# Altium schematic sheet REFERENCE.SchDoc
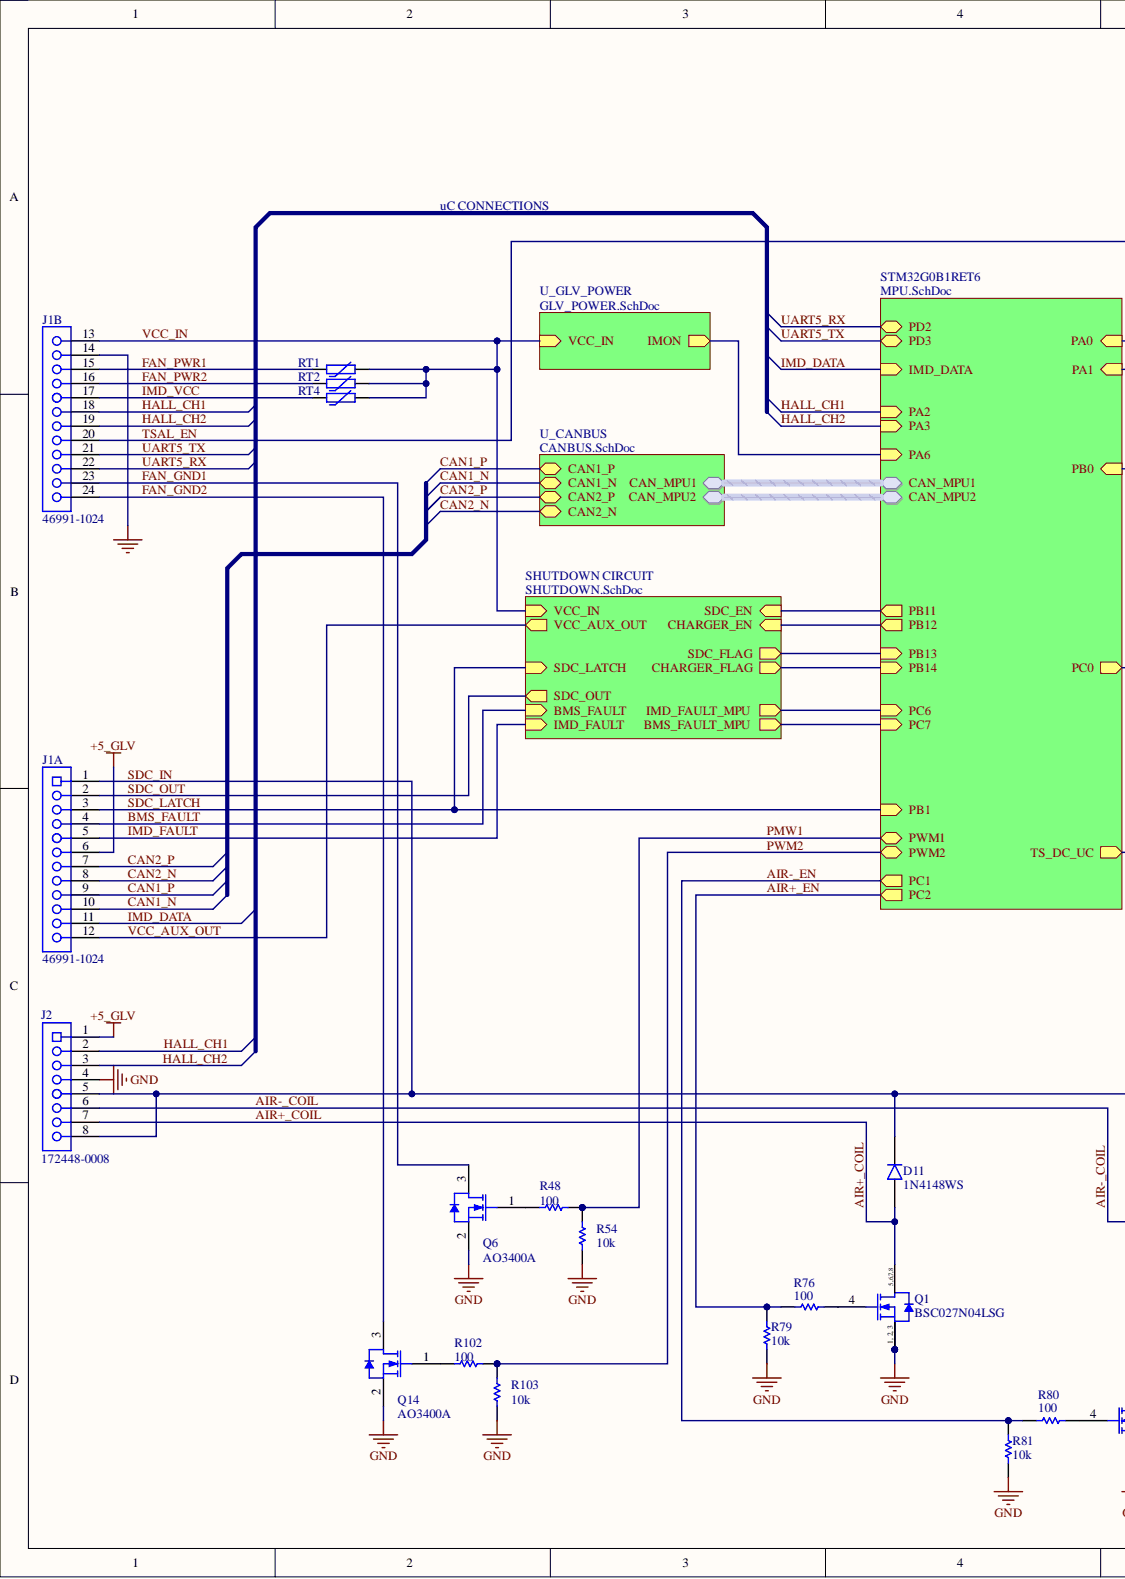
[diagram p0: REFERENCE.SchDoc, left]
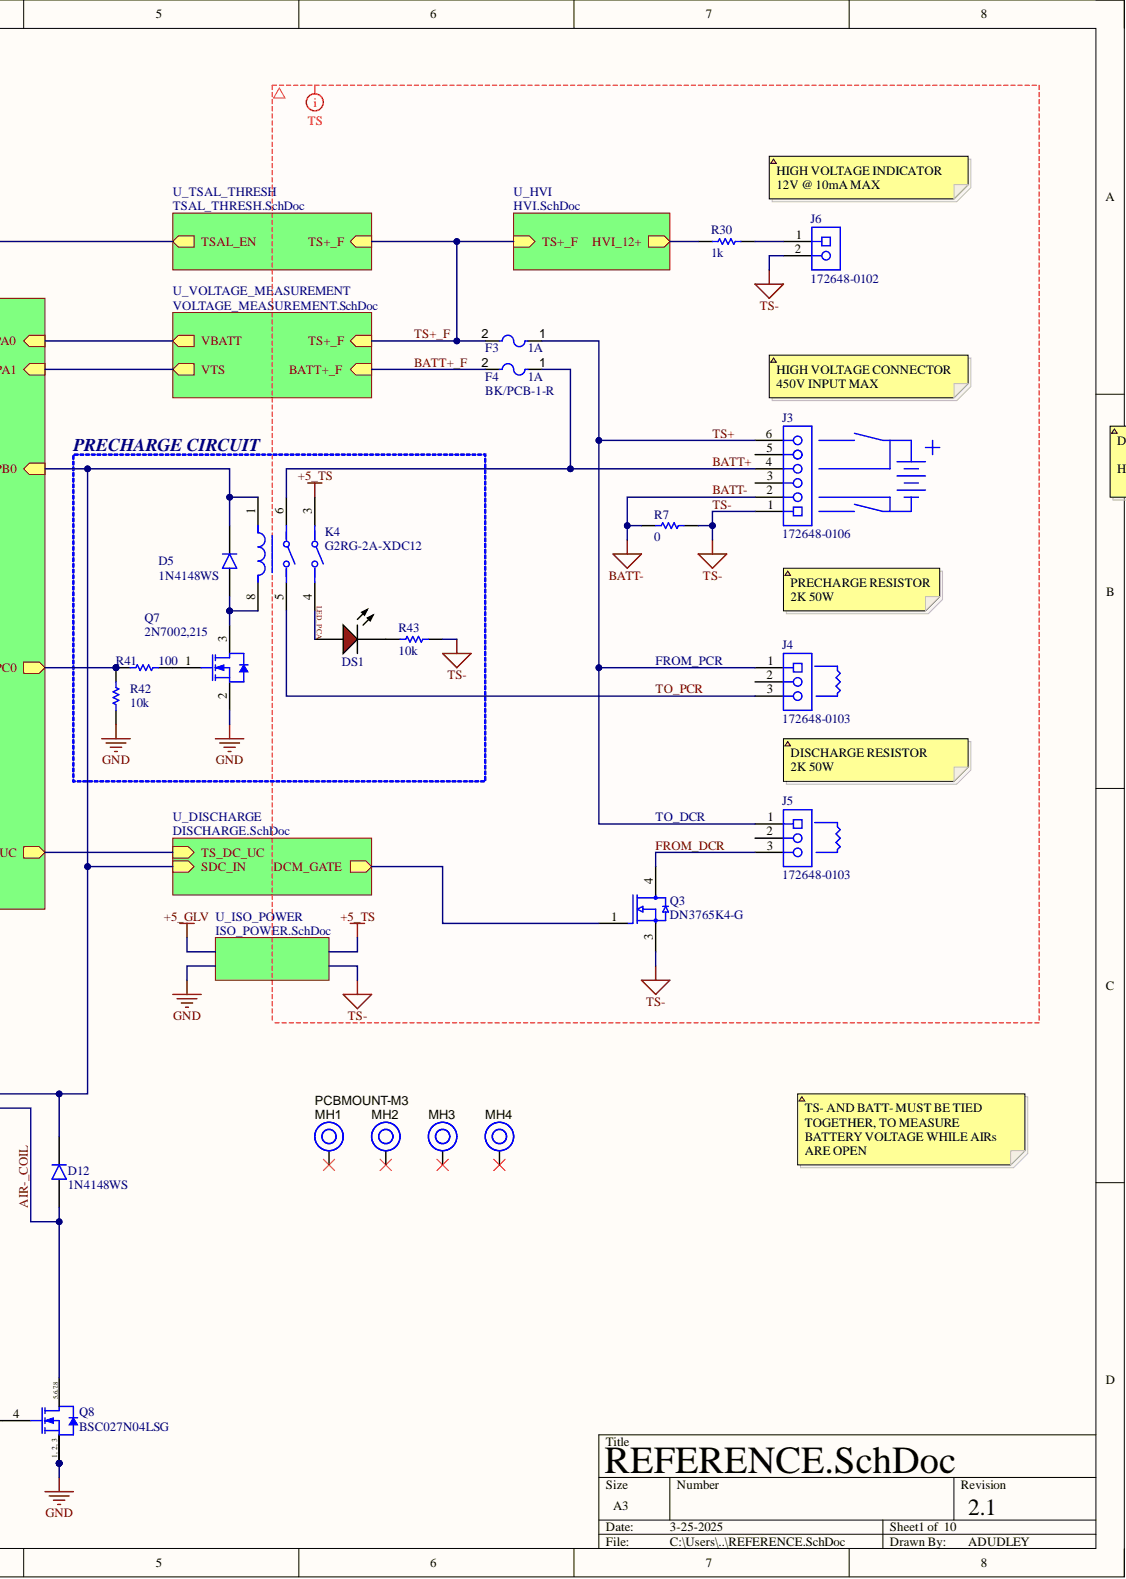
[diagram p1: REFERENCE.SchDoc, right]

## Parts
D5  1N4148WS  [FAIR-SOD-323_V]
D11  1N4148WS  [FAIR-SOD-323_V]
D12  1N4148WS  [FAIR-SOD-323_V]
DS1  19-217/R6C-AL1M2VY/3T  [EVRL-0603-2_V]  (value not drawn)
F3  Current Rating: 1A  [BK_PCB-1-R-Footprint-1]  (hidden comment: BK/PCB-1-R)
F4  BK/PCB-1-R  Current Rating: 1A  [BK_PCB-1-R-Footprint-1]
J1  46991-1024  [46991-1024-Footprint-1]  (parts A,B)
J2  172448-0008  [FP-172448-0008-MFG]
J3  172648-0106  [172648-0106-Footprint-1]
J4  172648-0103  [172648-0103-Footprint-1]
J5  172648-0103  [172648-0103-Footprint-1]
J6  172648-0102  [FP-172648-0102-MFG]
K4  G2RG-2A-XDC12  [G2RG-2A-XDC12-Footprint-1]
MH1  PCBMOUNT-M3  [PCBMOUNT-M3-Footprint-1]
MH2  PCBMOUNT-M3  [PCBMOUNT-M3-Footprint-1]
MH3  PCBMOUNT-M3  [PCBMOUNT-M3-Footprint-1]
MH4  PCBMOUNT-M3  [PCBMOUNT-M3-Footprint-1]
Q1  BSC027N04LSG  [INF-PG-TDSON-8-1_V]
Q3  DN3765K4-G  [FP-K4-IPC_C]
Q6  AO3400A  [NXP-SOT23-3_V]
Q7  2N7002,215  [NXP-SOT23-3_V]
Q8  BSC027N04LSG  [INF-PG-TDSON-8-1_V]
Q14  AO3400A  [NXP-SOT23-3_V]
R7  value 0  [RESC3116X70X50ML13T20]  (hidden comment: Jumper 1206)
R30  value 1k  [RESC3116X70X50ML13T20]  (hidden comment: 1K 1% 1206)
R41  value 100  [RESC1608X55X25ML10T15]  (hidden comment: 100R 1 0603)
R42  value 10k  [RESC1608X55X25ML10T15]  (hidden comment: 10K 1 0603)
R43  value 10k  [RESC1608X55X25ML10T15]  (hidden comment: 10K 1 0603)
R48  value 100  [RESC1608X55X25ML10T15]  (hidden comment: 100R 1 0603)
R54  value 10k  [RESC1608X55X25ML10T15]  (hidden comment: 10K 1 0603)
R76  value 100  [RESC1608X55X25ML10T15]  (hidden comment: 100R 1 0603)
R79  value 10k  [RESC1608X55X25ML10T15]  (hidden comment: 10K 1 0603)
R80  value 100  [RESC1608X55X25ML10T15]  (hidden comment: 100R 1 0603)
R81  value 10k  [RESC1608X55X25ML10T15]  (hidden comment: 10K 1 0603)
R102  value 100  [RESC1608X55X25ML10T15]  (hidden comment: 100R 1 0603)
R103  value 10k  [RESC1608X55X25ML10T15]  (hidden comment: 10K 1 0603)
RT1  MINISMDC260F/16-2  [FP-MINISMDC260F-16-2-MFG]  (value not drawn)
RT2  MINISMDC260F/16-2  [FP-MINISMDC260F-16-2-MFG]  (value not drawn)
RT4  MINISMDC260F/16-2  [FP-MINISMDC260F-16-2-MFG]  (value not drawn)

## Nets
+5_GLV: J1.6 J2.1
+5_TS: K4.3
GND: J1.14 J2.4 Q1.1 Q1.2 Q1.3 Q6.2 Q7.2 Q8.1 Q8.2 Q8.3 Q14.2 R42.1 R54.1 R79.1 R81.1 R103.1
AIR+_COIL: D11.1 J2.7 Q1.5,6,7,8
AIR+_EN: R76.1 R79.2
AIR-_COIL: D12.1 J2.6 Q8.5,6,7,8
AIR-_EN: R80.1 R81.2
BATT+: F4.1 J3.4 K4.6
BATT+_F: F4.2
BATT-: J3.2 R7.1
BMS_FAULT: J1.4
CAN1_N: J1.10
CAN1_P: J1.9
CAN2_N: J1.8
CAN2_P: J1.7
FAN_GND1: J1.23 Q6.3
FAN_GND2: J1.24 Q14.3
FAN_PWR1: J1.15 RT1.1
FAN_PWR2: J1.16 RT2.1
FROM_DCR: J5.3 Q3.4
HALL_CH1: J1.18 J2.2
HALL_CH2: J1.19 J2.3
IMD_DATA: J1.11
IMD_FAULT: J1.5
IMD_VCC: J1.17 RT4.1
LED_PCA: DS1.1 K4.4
PMW1: R48.2 R54.2
PWM2: R102.2 R103.2
SDC_IN: D5.2 D11.2 D12.2 J1.1 J2.5 J2.8 K4.1
SDC_LATCH: J1.3
SDC_OUT: J1.2
TO_PCR: J4.3 K4.5
TS+: F3.1 J3.6 J4.1 J5.1
TS+_F: F3.2
TS-: J3.1 J6.2 Q3.3 R7.2 R43.1
TSAL_EN: J1.20
UART5_RX: J1.22
UART5_TX: J1.21
VCC_AUX_OUT: J1.12
VCC_IN: J1.13 RT1.2 RT2.2 RT4.2
NetD5_1: D5.1 K4.8 Q7.3
NetDS1_2: DS1.2 R43.2
NetJ3_3: J3.3
NetJ3_5: J3.5
NetJ4_2: J4.2
NetJ5_2: J5.2
NetJ6_1: J6.1 R30.2
NetMH1_1: MH1.1
NetMH2_1: MH2.1
NetMH3_1: MH3.1
NetMH4_1: MH4.1
NetQ1_4: Q1.4 R76.2
NetQ3_1: Q3.1
NetQ6_1: Q6.1 R48.1
NetQ7_1: Q7.1 R41.2
NetQ8_4: Q8.4 R80.2
NetQ14_1: Q14.1 R102.1
NetR30_1: R30.1
NetR41_1: R41.1 R42.2
N00001:
N00002:
N00003:
N00004:
N00005:
N00006:
N00007:
N00008:
N00009:
N00010:
N00011:
N00012:
N00013:
N00014:

## Library data of the parts
D5 D11 D12 = CMP-004-00001-4: Small Signal Diode, 75 V, 0.15 A, -55 to 150 degC, 2-Pin SOD-323, RoHS, Tape and Reel; Manufacturer: Fairchild; Capacitance: -323f; Forward Current: 150mA; Forward Voltage: 1V; Peak Reverse Current: 5uA; Recovery Time: 4ns; Case/Package: SOD-323; Component Kind: Standard; Datasheet URL: https://www.fairchildsemi.com/datasheets/1N/1N4148WS.pdf; Datasheet Version: Rev. 1.2, 03/2015; LCSC Part Number: C2128; Manufacturer URL: https://www.fairchildsemi.com/; Max Operating Temperature: 150°C; Min Operating Temperature: -55°C; Mounting Technology: SM; Package Description: 2-Pin Small Outline Diode Flat Lead, Body 1.7 x 1.25 mm; Package Quantity: 3000; Package Reference: SOD-323; Packaging: TapeandReel; Pins: 2; RoHS Compliant: True; Library Name: Dalhousie Formula SAE
DS1 = CMP-007-00004-3: Chip LED, Red, 5 V, 25 mA, -40 to 85 degC, 2-Pin SMD (0603), RoHS, Tape and Reel; Manufacturer: Everlight Electronics; Forward Voltage: 2.2V; Lens Style: Clear; Case/Package: 0603; Colour: Red; Component Kind: Standard; Datasheet URL: https://datasheet.ciiva.com/4688/el-19-217-r6c-al1m2vy-3tpdf-187026-4688713.pdf; Datasheet Version: Rev. 1, 01/2005; LCSC Part Number: C2286; Manufacturer URL: http://www.everlight.com/; Mounting Technology: SM; Package Description: 2-Pin Surface Mount Device, Body 1.6 x 0.8 mm; Package Reference: 0603; RoHS Compliant: False; Library Name: Dalhousie Formula SAE
F3 F4 = CMP-005-00016-2: Electric Fuse, Fast Blow, 1A, 250VAC, 450VDC, 50A (IR), Through Hole; Body Breadth: 4.67inch; Body Height: 8.77mm; Body Length or Diameter: 8.89mm; Fuse Class: Supplemental; Joule-integral-Nom: 0.02J; Reference Standard: UL; Trip Time or Delay: 14400s; Voltage Rating: 250V; Voltage Rating (DC): 450V; Blow Characteristic: FAST; Circuit Protection Type: Electric Fuse; Component Kind: Standard; LCSC Part Number: C3160672; Mounting Technology: Through Hole; Packaging: Box; Rated Breaking Capacity: 50A; Reach SVHC Compliant: Yes; RoHS Compliant: Yes; Termination: Pin Wire; Voltage Rating (AC): 250V; Library Name: Dalhousie Formula SAE
J1 = CMP-002-00135-3: Rectangular Power Connector, 24 Contact(s), Male, Solder Terminal; Board Mounting Option: HOLE .064-.072; Body Breadth: 0.378inch; Body Depth: 0.796inch; Body Length: 2.031inch; Connector Type: Power,Rectangular; Contact Resistance: 10mR; Contact Style: SQ PIN-SKT; Insulation Resistance: 1GR; Mixed Contacts: Yes; Mounting Option 1: LOCKING; Number Of Connectors: 1; Number of Contacts: 24; Plating Thickness: 100uin; Reliability: COMMERCIAL; Throw Configuration: S0P24; Component Kind: Standard; Contact Finish - Termination: TIN OVER NICKEL; Contact Gender: Male; Insulation Color: Black; Insulation Material: Polymer; JESD-609 Code: e3; Max Operating Temperature: 105°C; Min Operating Temperature: -40°C; Mounting Style: RIGHT ANGLE; Mounting Technology: Board; Reach SVHC Compliant: Yes; RoHS Compliant: Yes; Termination: Solder; Library Name: Dalhousie Formula SAE
J2 = CMP-002-00093-3: Mini-Fit Jr. Header, Dual Row, Right-Angle, with Snap-in Plastic Peg PCB  Lock, 8 Circuits, PA Polyamide Nylon 6/6 94V-2, Tin (Sn) Plating, Glow-Wire Capable; Glow Wire Compliant: Compliant; Number of Circuits: 8; Pitch: 0.165inch; Color: Black; Component Kind: Standard; Contact Plating: Tin; Contact Type: Male Pin; Fastening Type: Ramp; Mounting Technology: Through Hole; Number of Positions: 8; Number of Rows: 2; Orientation: Right Angle; Packaging: Tray; RoHS Compliant: Yes; Row Spacing: 0.165inch; Series: Mini-Fit® Jr™ 172448; Termination: Solder; Library Name: Dalhousie Formula SAE
J3 = CMP-002-00099-2: Conn Power HDR 6 POS 4.2mm Solder RA Thru-Hole Tray; Component Kind: Standard; Library Name: Dalhousie Formula SAE
J4 J5 = CMP-002-00104-2: Rectangular Power Connector; Connector Type: Power,Rectangular; Component Kind: Standard; Reach SVHC Compliant: Yes; RoHS Compliant: Yes; Library Name: Dalhousie Formula SAE
J6 = CMP-002-00101-3: Cross Referenced to MOLEX - Part MOL172648-0102; Component Kind: Standard; Library Name: Dalhousie Formula SAE
K4 = CMP-008-00013-2: Power Relay DPST-NO 500VDC 12VDC PC Pins Through Hole Tray; Coil Current: 66.6 mA; Coil Resistance: 180 Ohms; Contact Form: DPST-NO (2 Form A); Contact Rating (Current): 10A; Switching Voltage: 500VDC - Max; Coil Voltage: 12VDC; Component Kind: Standard; Library Name: Dalhousie Formula SAE
MH1-MH4 = CMP-00028-00008-4: Mounting hole, M3 screw; Component Kind: Standard; Library Name: Dalhousie Formula SAE
Q1 Q8 = CMP-013-00042-8: Power Field-Effect Transistor, 24A I(D), 40V, 0.0041ohm, 1-Element, N-Channel, Silicon, Metal-oxide Semiconductor-FET; Case Connection: DRAIN; Drain Current-Max (Abs) (ID): 100A; Drain-source On Resistance-Max: 4.1mR; Operating Mode: ENHANCEMENT MODE; Power Dissipation-Max (Abs): 83W; Pulsed Drain Current-Max (IDM): 400A; Component Kind: Standard; Drain Current-Max (ID): 24A; DS Breakdown Voltage-Min: 40V; FET Technology: METAL-OXIDE SEMICONDUCTOR; LCSC Part Number: C2802513; Max Operating Temperature: 150°C; Pins: 5; Polarity/Channel Type: N-CHANNEL; RoHS Compliant: Yes; Library Name: Dalhousie Formula SAE
Q3 = CMP-013-00037-2: Trans MOSFET N-CH Si 650V 0.3A 3-Pin(2+Tab) DPAK T/R; Continuous Drain Current (ID): 300mA; Drain to Source Resistance: 8R; Fall Time: 100ns; Gate to Source Voltage (Vgs): 20V; Input Capacitance: 825pF; Max Power Dissipation: 2.5W; Number of Channels: 1; Polarity: N-CHANNEL; Case/Package: TO-252; Component Kind: Standard; Drain to Source Breakdown Voltage: 650V; Drain to Source Voltage (Vdss): 650V; Height: 2.39mm; Length: 6.73mm; Max Operating Temperature: 150°C; Min Operating Temperature: -55°C; Mounting Technology: Surface Mount; Number of Elements: 1; Package Quantity: 2000; Pins: 3; Power Dissipation: 2.5W; Rds On Max: 8R; Library Name: Dalhousie Formula SAE
Q6 Q14 = CMP-013-00044-2: Small Signal Field-Effect Transistor, 5.7A I(D), 30V, 1-Element, N-Channel, Silicon, Metal-oxide Semiconductor FET; Drain-source On Resistance-Max: 26.5mR; Number of Elements: 1; Operating Mode: ENHANCEMENT MODE; Package Body Material: Plastic; Transistor Application: SWITCHING; Component Kind: Standard; Drain Current-Max (ID): 5.7A; DS Breakdown Voltage-Min: 30V; FET Technology: METAL-OXIDE SEMICONDUCTOR; JESD-30 Code: R-PDSO-G3; LCSC Part Number: C20917; Package Shape: Rectangular; Package Style: SMALL OUTLINEMeter; Pins: 3; Polarity/Channel Type: N-CHANNEL; Reach SVHC Compliant: Yes; RoHS Compliant: Yes; Surface Mount: Yes; Terminal Form: Gull Wing; Terminal Position: DUAL; Transistor Element Material: SILICON; Library Name: Dalhousie Formula SAE
Q7 = CMP-013-00011-5: N-channel Trench MOSFET, 60 V, 300 mA, -65 to 150 degC, 3-Pin SOT-23 (TO-236AB), RoHS, Tape and Reel; Manufacturer: NXP Semiconductors; Elements: 1; Input Capacitance: 50pF; Max Dual Supply Voltage: 60V; Nominal Vgs: 2V; Package Version: Feb-16; Power: 830mW; Rds On Max: 5Ohm; Case/Package: TO-236-3; Component Kind: Standard; Datasheet URL: http://www.nxp.com/documents/data_sheet/2N7002.pdf; Datasheet Version: Rev. 7, 09/2011; LCSC Part Number: C8545; Max Operating Temperature: 150°C; Min Operating Temperature: -65°C; Mounting Technology: SM; Nominal Dual Supply Voltage: 60V; Package Description: 3-Pin Small Outline Transistor, Body 1.3 x 2.9 mm, Pitch 0.95 mm; Package Quantity: 1; Package Reference: SOT23-3; Packaging: TapeandReel; Pins: 8; RoHS Compliant: True; Vds Max: 60V; Library Name: Dalhousie Formula SAE
R7 = CMP-0105-0510-5: Jumper 1206 (3216 Metric); Case-EIA: 1206; Case-Metric: 3216; Power: 250mW; Temp. Coefficent: None; Tolerance: 0%; Case/Package: 1206; Component Kind: Standard; Technology: SMT; Library Name: Dalhousie Formula SAE
R30 = CMP-0105-0583-6: 1206 (3216 Metric) 1/4 W 1K 1 Thick Film Chip Resistor; Case-EIA: 1206; Case-Metric: 3216; Power: 250mW; Temp. Coefficent: ±100; Case/Package: 1206; Component Kind: Standard; LCSC Part Number: C2907372; Technology: SMT; Tolerance: 1%; Library Name: Dalhousie Formula SAE
R41 R48 R76 R80 R102 = CMP-0103-0559-8: 0603 (1608 Metric) 1/10 W 100R 1 Thick Film Chip Resistor; Case-EIA: 0603; Case-Metric: 1608; Power: 100mW; Temp. Coefficent: ±100; Tolerance: 1%; Case/Package: 0603; Component Kind: Standard; LCSC Part Number: C22775; Technology: SMT; Library Name: Dalhousie Formula SAE
R42 R43 R54 R79 R81 R103 = CMP-0103-0607-9: 0603 (1608 Metric) 1/10 W 10K 1 Thick Film Chip Resistor; Case-EIA: 0603; Case-Metric: 1608; Power: 100mW; Temp. Coefficent: ±100; Tolerance: 1%; Case/Package: 0603; Component Kind: Standard; LCSC Part Number: C25804; Technology: SMT; Library Name: Dalhousie Formula SAE
RT1 RT2 RT4 = CMP-005-00019-3: PTC Resettable Fuse, 16V, Surface Mount; Thermistor Application: OVERLOAD PROTECTION; Component Kind: Standard; JESD-609 Code: e3; LCSC Part Number: C16490; Mounting Technology: Surface Mount; Package Shape: Rectangular; Pins: 2; Reach SVHC Compliant: Yes; Resistor Type: PTC THERMISTOR; RoHS Compliant: Yes; Terminal Finish: Nickel; Terminal Placement: Dual Ended; Termination: Wraparound; Library Name: Dalhousie Formula SAE
